# Altium schematic sheet DIGITAL_IO.SchDoc
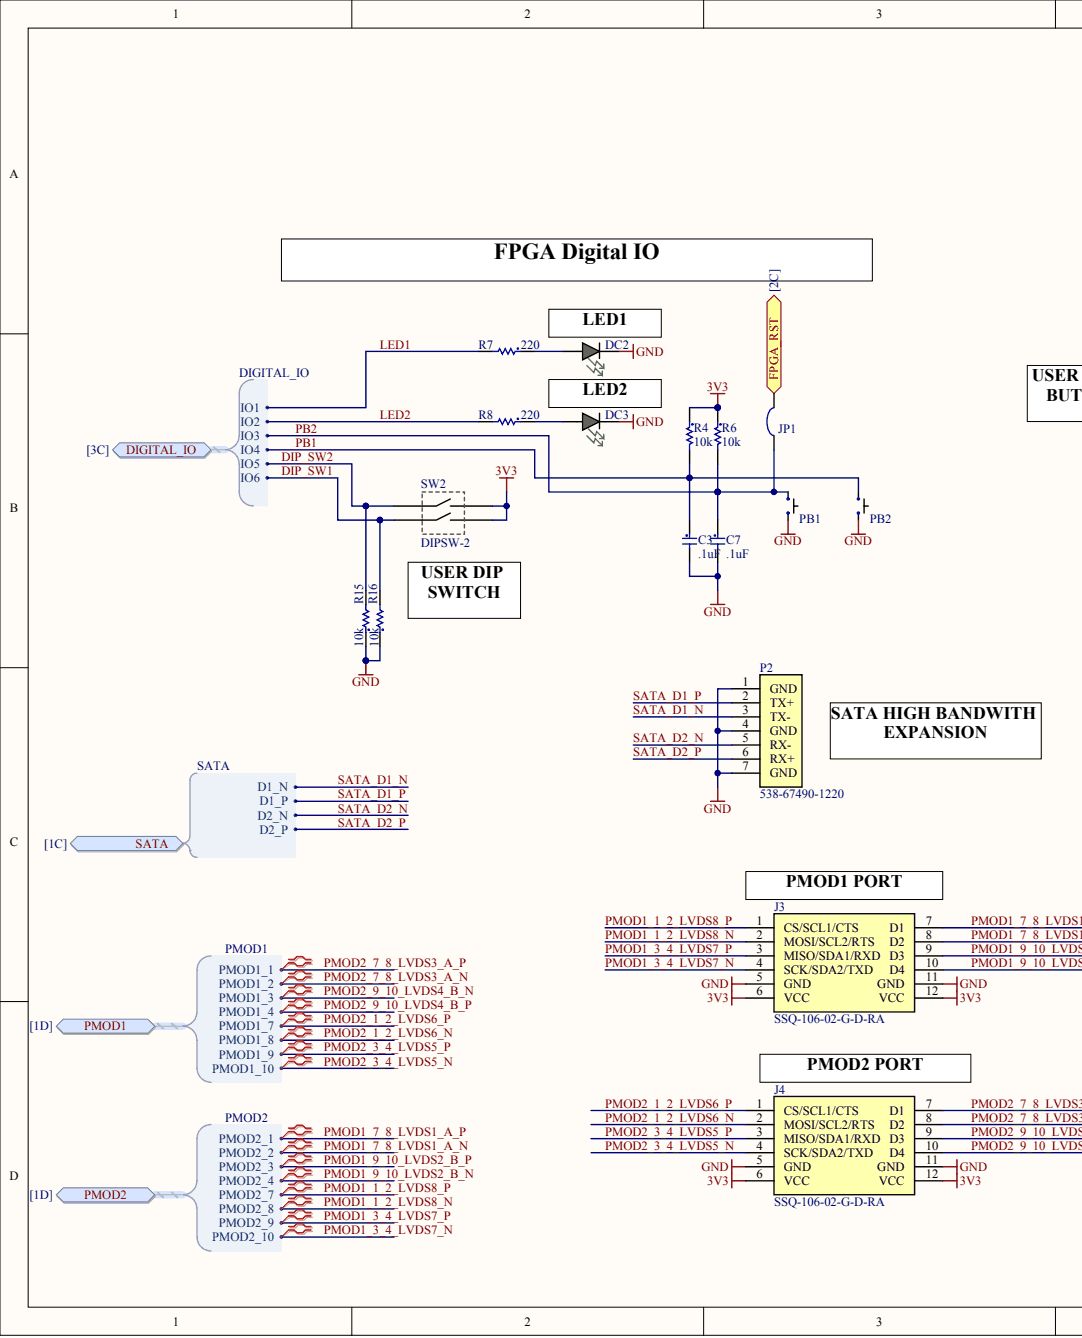
[diagram p0: DIGITAL_IO.SchDoc, left]
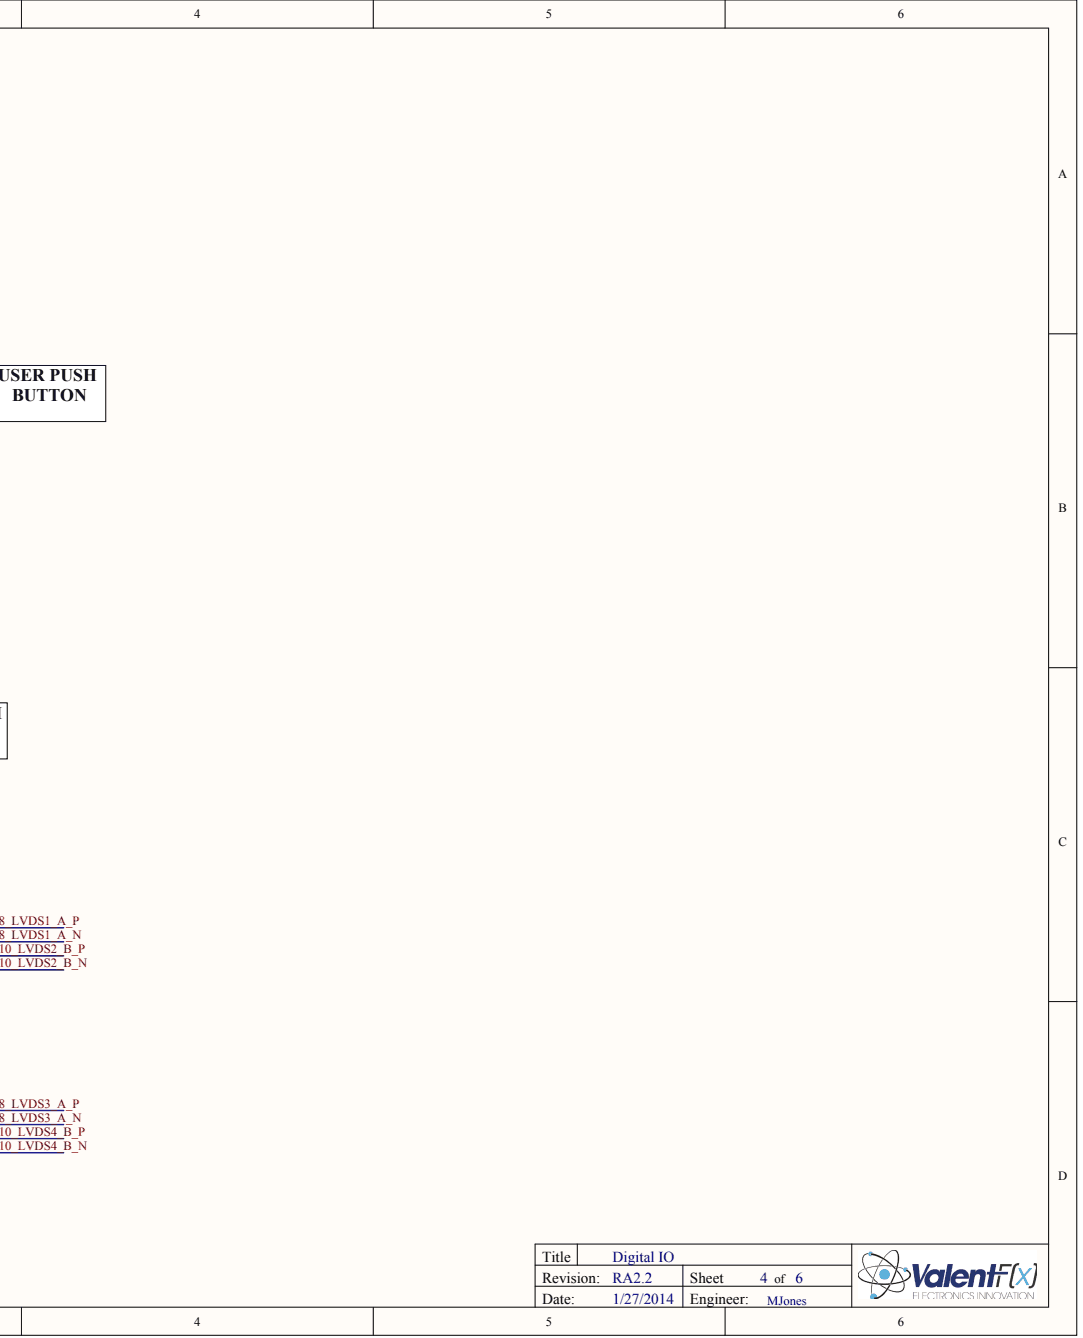
[diagram p1: DIGITAL_IO.SchDoc, right]

## Parts
C3  .1uF
C7  .1uF
DC2  =Value
DC3  =Value
J3  SSQ-106-02-G-D-RA
J4  SSQ-106-02-G-D-RA
JP1  Solder Jumper  (value not drawn)
P2  Supplier Part Number 1: 538-67490-1220  (hidden comment: SATA - 67490-1220)
PB1  =Value
PB2  =Value
R4  10k
R6  10k
R7  220
R8  220
R15  10k
R16  10k
SW2  DIPSW-2  Switch Type: DIP

## Ports
DIGITAL_IO, DIGITAL_IO.IO1, DIGITAL_IO.IO2, DIGITAL_IO.IO3, DIGITAL_IO.IO4, DIGITAL_IO.IO5, DIGITAL_IO.IO6, FPGA_RST, PMOD1, PMOD1.PMOD1_1, PMOD1.PMOD1_2, PMOD1.PMOD1_3, PMOD1.PMOD1_4, PMOD1.PMOD1_7, PMOD1.PMOD1_8, PMOD1.PMOD1_9, PMOD1.PMOD1_10, PMOD2, PMOD2.PMOD2_1, PMOD2.PMOD2_2, PMOD2.PMOD2_3, PMOD2.PMOD2_4, PMOD2.PMOD2_7, PMOD2.PMOD2_8, PMOD2.PMOD2_9, PMOD2.PMOD2_10, SATA, SATA.D1_N, SATA.D1_P, SATA.D2_N, SATA.D2_P

## Nets
3V3: J3.6(VCC) J3.12(VCC) J4.6(VCC) J4.12(VCC) R4.1 R6.1 SW2.B1 SW2.B2
GND: C3.2 C7.2 DC2.C DC3.C J3.5(GND) J3.11(GND) J4.5(GND) J4.11(GND) P2.1(GND) P2.4(GND) P2.7(GND) PB1.A PB2.A R15.1 R16.1
DIP_SW1: R16.2 SW2.A2 ; port DIGITAL_IO
DIP_SW2: R15.2 SW2.A1 ; port DIGITAL_IO
FPGA_RST: JP1.2 ; port FPGA_RST
LED1: R7.2 ; port DIGITAL_IO
LED2: R8.2 ; port DIGITAL_IO
PB1: C3.1 PB2.B R4.2 ; port DIGITAL_IO
PB2: C7.1 JP1.1 PB1.B R6.2 ; port DIGITAL_IO
PMOD1_1_2_LVDS8_N: J3.2(MOSI/SCL2/RTS) ; port PMOD2
PMOD1_1_2_LVDS8_P: J3.1(CS/SCL1/CTS) ; port PMOD2
PMOD1_3_4_LVDS7_N: J3.4(SCK/SDA2/TXD) ; port PMOD2
PMOD1_3_4_LVDS7_P: J3.3(MISO/SDA1/RXD) ; port PMOD2
PMOD1_7_8_LVDS1_A_N: J3.8(D2) ; port PMOD2
PMOD1_7_8_LVDS1_A_P: J3.7(D1) ; port PMOD2
PMOD1_9_10_LVDS2_B_N: J3.10(D4) ; port PMOD2
PMOD1_9_10_LVDS2_B_P: J3.9(D3) ; port PMOD2
PMOD2_1_2_LVDS6_N: J4.2(MOSI/SCL2/RTS) ; port PMOD1
PMOD2_1_2_LVDS6_P: J4.1(CS/SCL1/CTS) ; port PMOD1
PMOD2_3_4_LVDS5_N: J4.4(SCK/SDA2/TXD) ; port PMOD1
PMOD2_3_4_LVDS5_P: J4.3(MISO/SDA1/RXD) ; port PMOD1
PMOD2_7_8_LVDS3_A_N: J4.8(D2) ; port PMOD1
PMOD2_7_8_LVDS3_A_P: J4.7(D1) ; port PMOD1
PMOD2_9_10_LVDS4_B_N: J4.10(D4) ; port PMOD1
PMOD2_9_10_LVDS4_B_P: J4.9(D3) ; port PMOD1
SATA_D1_N: P2.3(TX-) ; port SATA
SATA_D1_P: P2.2(TX+) ; port SATA
SATA_D2_N: P2.5(RX-) ; port SATA
SATA_D2_P: P2.6(RX+) ; port SATA
NetDC2_A: DC2.A R7.1
NetDC3_A: DC3.A R8.1

## Library data of the parts
C3 C7 = (no symbol name): Manufacturer: Murata; Manufacturer Part Number: GRM155R61C104KA88D; Capacitance: 0.1 uF; Tolerance: 10 %; Voltage Rating: 16 Volts; Temperature Coefficient / Code: X5R; Value: .1uF; Supplier 1: Mouser; Supplier Part Number 1: 81-GRM155R61C104KA8D; RoHS: RoHS Compliant By Exemption; Category: Multilayer Ceramic Capacitors (MLCC) - SMD/SMT; Stock 1: 478408; Pricing 1: 1=0.122, 50=0.034, 100=0.026, 500=0.014, 1000=0.009, 10000=0.005, 20000=0.004 (AUD); Package / Case: 0402 (1005 metric); Packaging: Reel; ComponentLink1URL: http://www.murata.com/products/catalog/pdf/c02e.pdf; ComponentLink1Description: http://www.murata.com/products/catalog/pdf/c02e.pdf; Dimensions: 0.5 mm W x 1 mm L x 0.5 mm H; Operating Temperature Range: - 55 C to + 85 C; Product: General Type MLCCs; Series: GRM; Termination Style: SMD/SMT; Product Category: Low & Medium Capacitance SMD Ceramic Chip Capacitors; Standard Pack Qty: 10000; RoHS - Mouser: E; Minimum Order Quantity: 1; Reeling: True
DC2 DC3 = (no symbol name): Manufacturer: Kingbright Corp; Manufacturer Part Number: APT1608CGCK; Current - Test: 20mA; Wavelength - Dominant: 570nm; Wavelength - Peak: 574nm; Millicandela Rating: 50mcd; Voltage - Forward (Vf) Typ: 2.1V; Lens Type: Clear; Lens Style/Size: Rectangle with Flat Top, 1.2mm x 0.8mm; Viewing Angle: 120°; Supplier 1: Digi-Key; RoHS: RoHS Compliant; Category: Optoelectronics; Stock 1: 98122; Pricing 1: 1=0.2, 10=0.153, 25=0.1224, 100=0.1105, 250=0.102, 500=0.0918 (USD); Color: Green; Luminous Flux @ Current - Test: -; Size / Dimension: 1.60mm L x 0.80mm W; Height: 0.75mm; Package / Case: 0603 (1608 Metric); Mounting Type: Surface Mount; Packaging: Cut Tape (CT); ComponentLink1URL: http://www.us.kingbright.com/images/catalog/SPEC/APT1608CGCK.pdf; ComponentLink1Description: http://www.us.kingbright.com/images/catalog/SPEC/APT1608CGCK.pdf
J3 J4 = (no symbol name): Manufacturer: SAMTEC; Supplier 1: Samtec; Supplier Part Number 1: SSQ-106-02-G-D-RA; Manufacturer Part Number: SSQ-106-02-G-D-RA; Stock 1: 0; Pricing 1: 1=1.58, 100=1.58, 1000=1.58 (USD); Value: SSQ-106-02-G-D-RA
P2 = (no symbol name): Manufacturer: Molex; 1Notes: looks more standard need, different footprint; Gender: Male; Pitch: 1.27 mm; Number of Positions / Contacts: 7; Supplier 1: Mouser; Manufacturer Part Number: 67490-1220; RoHS: RoHS Compliant; Category: I/O Connectors; Stock 1: 475; Pricing 1: 1=1.28, 10=1.07, 100=0.792, 500=0.640, 1000=0.572, 2000=0.525 (AUD); Packaging: Tray; Product: SATA Connectors; Termination Style: Solder Terminal; Mounting Style: SMD/SMT; Product Category: 1.27mm Connectors; Standard Pack Qty: 2880; RoHS - Mouser: Y; Minimum Order Quantity: 1; Reeling: False; ComponentLink1URL: http://www.molex.com/webdocs/datasheets/pdf/en-us/0674901220_PCB_HEADERS.pdf; ComponentLink1Description: http://www.molex.com/webdocs/datasheets/pdf/en-us/0674901220_PCB_HEADERS.pdf
PB1 PB2 = (no symbol name): Manufacturer: TE Connectivity; Manufacturer Part Number: FSM4JSMA; Circuit: SPST-NO; Switch Function: Off-Mom; Contact Rating @ Voltage: 0.05A @ 12VDC; Actuator Type: Standard; Outline: 6.00mm x 6.00mm; Illumination: Non-Illuminated; Operating Force: 160gf; Actuator Height off PCB, from Pins: 5.00mm; Actuator Orientation: Top Actuated; Actuator Material: Polyphenylene Sulfide (PPS); Switch Travel: 0.25mm; Mechanical Life: 100,000 Cycles; Supplier 1: Digi-Key; Supplier Part Number 1: 450-1129-ND; RoHS: RoHS Compliant; Category: Switches; Stock 1: 13038; Pricing 1: 1=0.26, 10=0.246, 25=0.2096, 50=0.1834, 100=0.1703, 250=0.15064, 500=0.1441, 1000=0.1179, 5000=0.09825 (USD); Mounting Type: Surface Mount; Termination Style: Gull Wing; Packaging: Bulk; ComponentLink1URL: http://www.te.com/commerce/DocumentDelivery/DDEController?Action=srchrtrv&DocNm=2-1437565-7&DocType=Customer+Drawing&DocLang=English; ComponentLink1Description: http://www.te.com/commerce/DocumentDelivery/DDEController?Action=srchrtrv&DocNm=2-1437565-7&DocType=Customer+Drawing&DocLang=English; Illumination Voltage (Nominal): -; Illumination Type, Color: -; Ingress Protection: -; Features: -; Operating Temperature: -35°C ~ 85°C
R4 R6 R15 R16 = (no symbol name): Manufacturer: Vishay Dale; Manufacturer Part Number: CRCW060310K0FKEA; Power (Watts): 0.1W, 1/10W; Temperature Coefficient: ±100ppm/°C; Tolerance: ±1%; Supplier 1: Digi-Key; RoHS: RoHS Compliant; Category: Resistors; Stock 1: 997856; Pricing 1: 10=0.081, 50=0.0436, 200=0.0251, 1000=0.01711 (USD); Resistance (Ohms): 10K; Composition: Thick Film; Features: -; Package / Case: 0603 (1608 Metric); Size / Dimension: 0.061" L x 0.033" W (1.55mm x 0.85mm); Height: 0.020" (0.50mm); Number of Terminations: 2; Packaging: Cut Tape (CT); ComponentLink1URL: http://www.vishay.com/doc?20035; ComponentLink1Description: http://www.vishay.com/doc?20035; Supplier Part Number 1: 541-10.0KHCT-ND; Value: 10k
R7 R8 = (no symbol name): Manufacturer: Panasonic - ECG; Manufacturer Part Number: ERJ-3EKF2200V; Power (Watts): 0.1W, 1/10W; Temperature Coefficient: ±100ppm/°C; Tolerance: ±1%; Value: 220; Supplier 1: Digi-Key; Supplier Part Number 1: P220HCT-ND; RoHS: RoHS Compliant; Category: Resistors; Stock 1: 517798; Pricing 1: 1=0.1, 50=0.0138, 100=0.0105, 250=0.0078, 500=0.00576, 1000=0.00384 (USD); Resistance (Ohms): 220; Composition: Thick Film; Features: -; Size / Dimension: 0.063" L x 0.031" W (1.60mm x 0.80mm); Height: 0.022" (0.55mm); Packaging: Cut Tape (CT); Package / Case: 0603 (1608 Metric); ComponentLink1URL: http://industrial.panasonic.com/www-cgi/jvcr13pz.cgi?E+PZ+3+AOA0002+ERJ3EKF2200V+7+WW; ComponentLink1Description: http://industrial.panasonic.com/www-cgi/jvcr13pz.cgi?E+PZ+3+AOA0002+ERJ3EKF2200V+7+WW; Number of Terminations: 2
SW2 = (no symbol name): Manufacturer: CTS Electronic Components; Manufacturer Part Number: 219-2MST; Number of Positions: 2; Supplier 1: Mouser; RoHS: RoHS Compliant; Category: DIP Switches / SIP Switches; Stock 1: 3237; Pricing 1: 1=0.580, 10=0.530, 100=0.480, 500=0.350, 1000=0.300, 5000=0.270 (USD); Operating Temperature Range: - 55 C to + 85 C; Packaging: Tube; Terminal Pitch: 2.54 mm; Termination Style: SMD/SMT; Product Category: DIP Switches; RoHS - Mouser: Y; Minimum Order Quantity: 1; Reeling: False; ComponentLink1URL: http://www.ctscorp.com/components/Datasheets/219.pdf; ComponentLink1Description: http://www.ctscorp.com/components/Datasheets/219.pdf; Supplier Part Number 1: 774-2192MST
JP1 = (no symbol name): Manufacturer: n/a; Manufacturer Part Number: n/a; Source: n/a; Source Part Number: n/a; ROHS Certificate: n/a
